# Altium schematic sheet project_buran_schematic.SchDoc
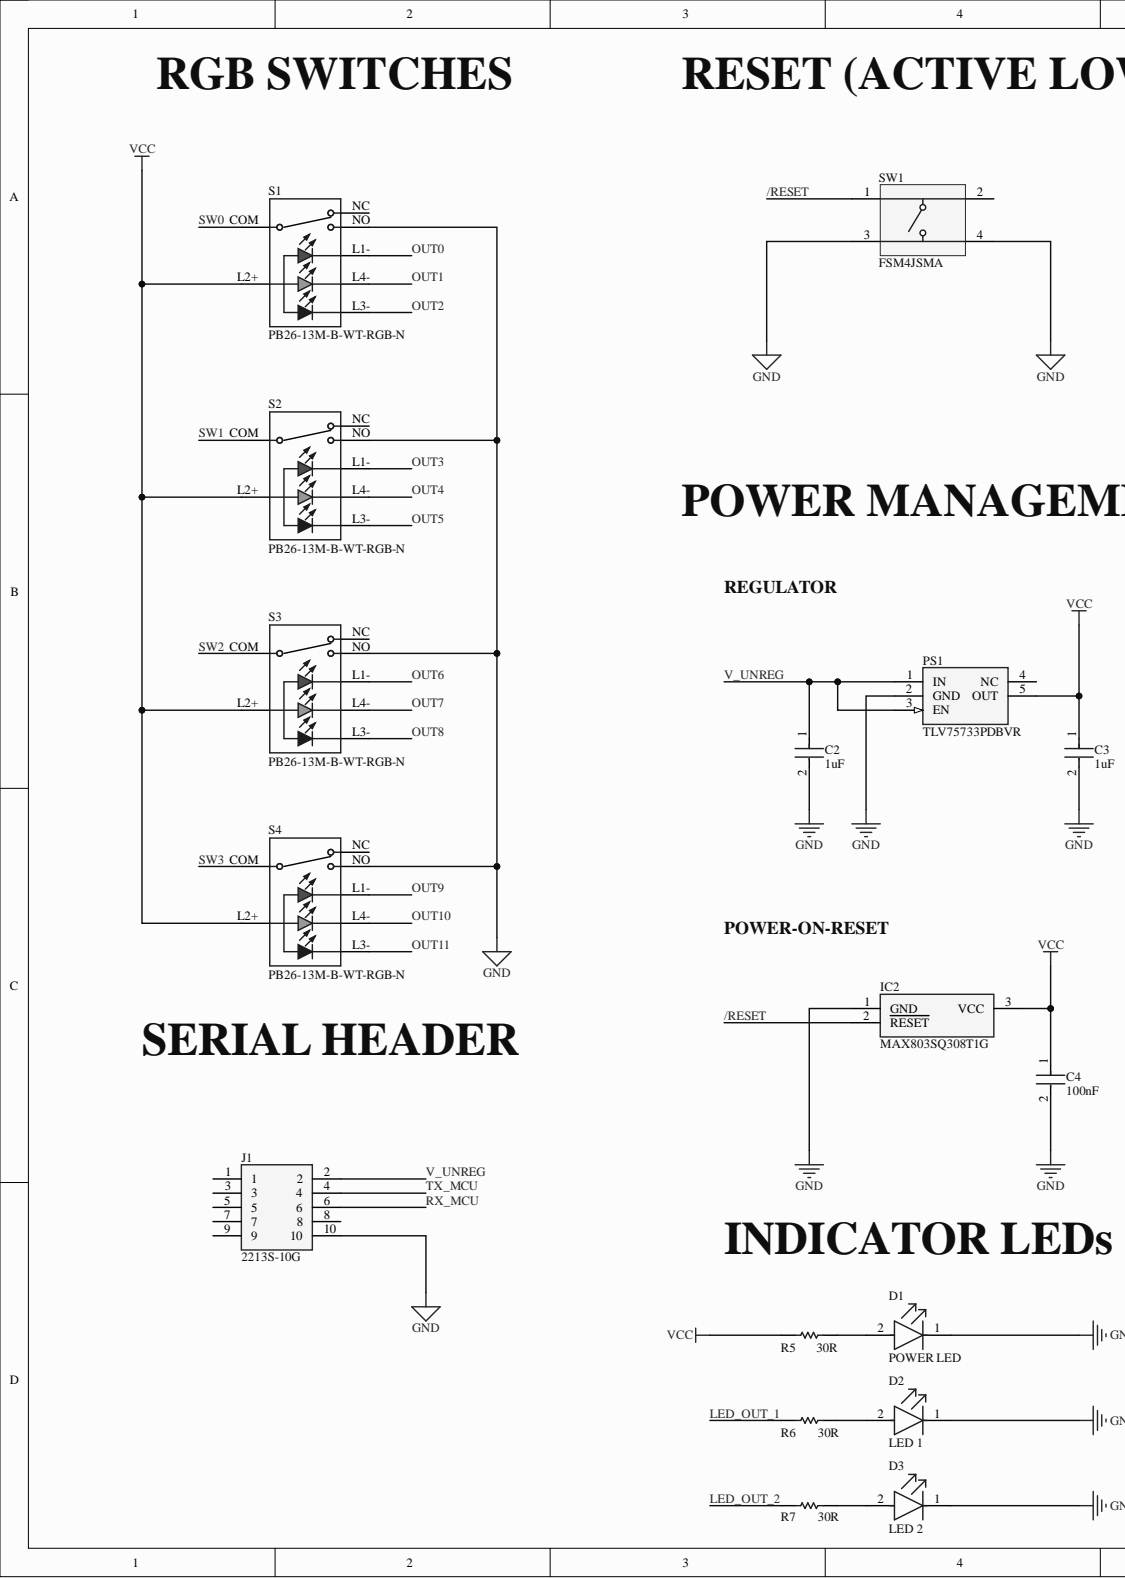
[diagram p0: project_buran_schematic.SchDoc, left]
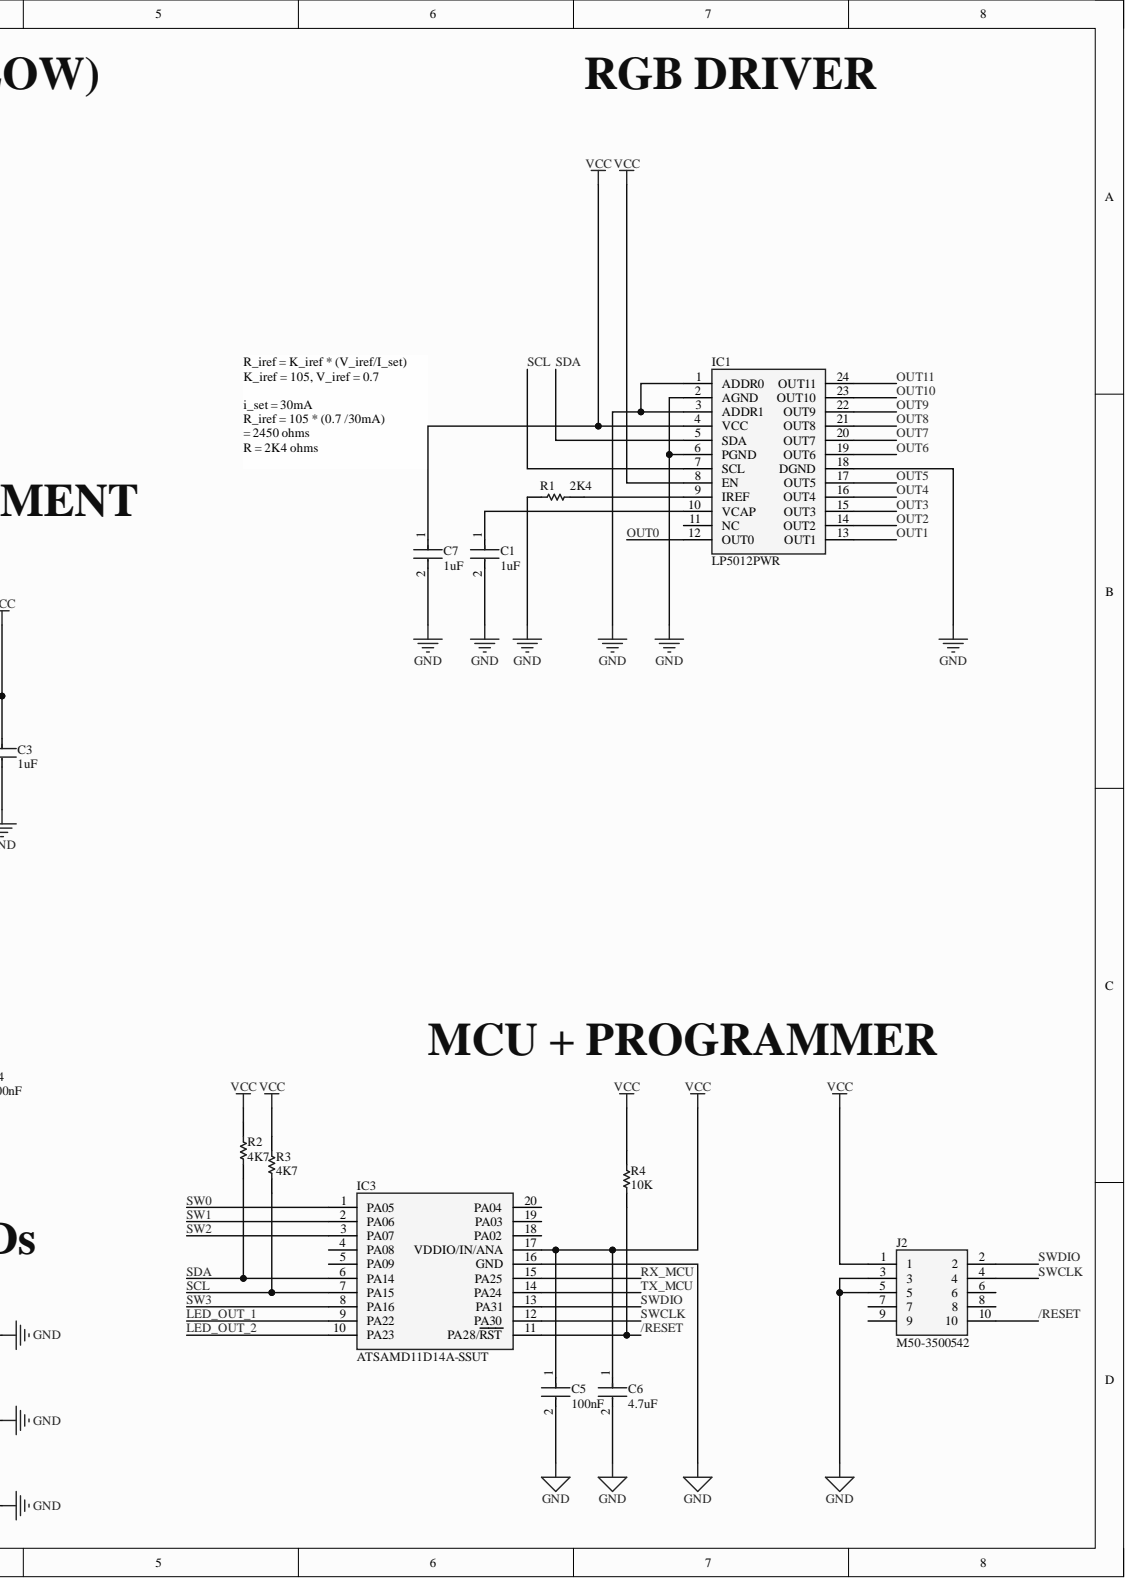
[diagram p1: project_buran_schematic.SchDoc, right]

## Parts
C1  1uF  [FP-GRM188-0_1-e0_2_0_55-IPC_A]
C2  1uF  [FP-GRM188-0_1-e0_2_0_55-IPC_A]
C3  1uF  [FP-GRM188-0_1-e0_2_0_55-IPC_A]
C4  100nF  [FP-GRM188-0_1-e0_2_0_55-IPC_A]
C5  100nF  [FP-GRM188-0_1-e0_2_0_55-IPC_A]
C6  4.7uF  [FP-GRM188-0_1-e0_2_0_55-IPC_A]
C7  1uF  [FP-GRM188-0_1-e0_2_0_55-IPC_A]
D1  POWER LED  [FP-LTST-C190GKT-MFG]
D2  LED 1  [FP-LTST-C190GKT-MFG]
D3  LED 2  [FP-LTST-C190GKT-MFG]
IC1  LP5012PWR  [SOP65P640X120-24N]
IC2  MAX803SQ308T1G  [SOT65P220X100-3N]
IC3  ATSAMD11D14A-SSUT  [SOIC127P1032X265-20N]
J1  2213S-10G  [HDRV10W64P254_2X5_1270X508X854P]
J2  M50-3500542  [M503500542]
PS1  TLV75733PDBVR  [SOT95P280X145-5N]
R1  2K4  [RESC1609X50X30NL10T20]
R2  4K7  [RESC1609X50X30NL10T20]
R3  4K7  [RESC1609X50X30NL10T20]
R4  10K  [RESC1609X50X30NL10T20]
R5  30R  [RESC1609X50X30NL10T20]
R6  30R  [RESC1609X50X30NL10T20]
R7  30R  [RESC1609X50X30NL10T20]
S1  PB26-13M-B-WT-RGB-N  [RGB Switch]
S2  PB26-13M-B-WT-RGB-N  [RGB Switch]
S3  PB26-13M-B-WT-RGB-N  [RGB Switch]
S4  PB26-13M-B-WT-RGB-N  [RGB Switch]
SW1  FSM4JSMA  [TECO-FSM4JSMA_V]

## Nets
GND: C1.2 C2.2 C3.2 C4.2 C5.2 C6.2 C7.2 D1.1 D2.1 D3.1 IC1.1(ADDR0) IC1.2(AGND) IC1.3(ADDR1) IC1.6(PGND) IC1.18(DGND) IC2.1 IC3.16(GND) J1.10 J2.3 J2.5 PS1.2(GND) R1.1 S1.NO S2.NO S3.NO S4.NO SW1.3 SW1.4
VCC: C3.1 C4.1 C5.1 C6.1 C7.1 IC1.4(VCC) IC1.8(EN) IC2.3 IC3.17(VDDIO/IN/ANA) J2.1 PS1.5(OUT) R2.2 R3.2 R4.2 R5.2 S1.L2+ S2.L2+ S3.L2+ S4.L2+
/RESET: IC2.2 IC3.11(PA28/RST) J2.10 R4.1 SW1.1
LED_OUT_1: IC3.9(PA22) R6.2
LED_OUT_2: IC3.10(PA23) R7.2
OUT0: IC1.12(OUT0) S1.L1-
OUT1: IC1.13(OUT1) S1.L4-
OUT2: IC1.14(OUT2) S1.L3-
OUT3: IC1.15(OUT3) S2.L1-
OUT4: IC1.16(OUT4) S2.L4-
OUT5: IC1.17(OUT5) S2.L3-
OUT6: IC1.19(OUT6) S3.L1-
OUT7: IC1.20(OUT7) S3.L4-
OUT8: IC1.21(OUT8) S3.L3-
OUT9: IC1.22(OUT9) S4.L1-
OUT10: IC1.23(OUT10) S4.L4-
OUT11: IC1.24(OUT11) S4.L3-
RX_MCU: IC3.15(PA25) J1.6
SCL: IC1.7(SCL) IC3.7(PA15) R3.1
SDA: IC1.5(SDA) IC3.6(PA14) R2.1
SW0: IC3.1(PA05) S1.COM
SW1: IC3.2(PA06) S2.COM
SW2: IC3.3(PA07) S3.COM
SW3: IC3.8(PA16) S4.COM
SWCLK: IC3.12(PA30) J2.4
SWDIO: IC3.13(PA31) J2.2
TX_MCU: IC3.14(PA24) J1.4
V_UNREG: C2.1 J1.2 PS1.1(IN) PS1.3(EN)
NetC1_1: C1.1 IC1.10(VCAP)
NetD1_2: D1.2 R5.1
NetD2_2: D2.2 R6.1
NetD3_2: D3.2 R7.1
NetIC1_9: IC1.9(IREF) R1.2

Not connected: IC1 11(NC); IC3 4(PA08) 5(PA09) 18(PA02) 19(PA03) 20(PA04); J1 1 3 5 7 8 9; J2 6 7 8 9; PS1 4(NC); S1 NC; S2 NC; S3 NC; S4 NC; SW1 2

## Library data of the parts
C1-C7 = CMP-2006-02792-2: Chip Multilayer Ceramic Capacitors for General Purpose, 0603, 10uF, X5R, 15%, 20%, 6.3V; Capacitance: 10uF; Case Code (Imperial): 0603; Case Code (Metric): 1608; Case/Package: 0603; Component Kind: Standard; Depth: 0.8mm; Dielectric: X5R; Height: 0.8mm; Lead Free: Lead Free; Length: 1.6mm; Library Name: Altium Content Vault; Material: Ceramic; Max Operating Temperature: 85°C; Min Operating Temperature: -55°C; Mount: Surface Mount; Package Quantity: 4000; Packaging: Tape and Reel; Radiation Hardening: No; REACH SVHC: No SVHC; Termination: SMD/SMT; Thickness: 0.035inch; Tolerance: 20%; Voltage: 6.3V; Voltage Rating: 6.3V; Voltage Rating (DC): 6.3V; Width: 0.031inch
D1-D3 = CMP-22017-000005-2: LED GREEN CLEAR CHIP SMD; Dominant Wavelength: 569nm; Forward Current: 30mA; Forward Voltage: 2.1V; Lens Color: Colorless; Luminous Intensity: 6mcd; Number of Elements: 1; Power Dissipation: 100mW; Reverse Voltage: 5V; Test Current: 10mA; Viewing Angle: 130°; Voltage: 2V; Wavelength: 565nm; Case Code (Imperial): 0603; Case Code (Metric): 1608; Case/Package: 0603; Color: Green; Component Kind: Standard; Depth: 0.8mm; Height: 0.8mm; Illumination Color: Green; Lead Free: Lead Free; Length: 1.6mm; Lens Style: Clear; Lens Transparency: Clear; Library Name: Altium Content Vault; Max Operating Temperature: 85°C; Min Operating Temperature: -55°C; Mount: Surface Mount; Number of LEDs: 1; Number of Pins: 2; Package Quantity: 1; Packaging: Tape and Reel; Power Rating: 100mW; Radiation Hardening: No; Width: 0.8mm
IC1 = LP5012PWR: Integrated Circuit; Manufacturer_Name: Texas Instruments; Component Kind: Standard; Datasheet Link: https://www.ti.com/lit/ds/symlink/lp5012.pdf?ts=1615929314042&ref_url=https%253A%252F%252Fwww.mouser.co.uk%252F; Height: 1.2mm; Manufacturer_Part_Number: LP5012PWR; Mouser Part Number: 595-LP5012PWR; Mouser Price/Stock: https://www.mouser.co.uk/ProductDetail/Texas-Instruments/LP5012PWR/?qs=T94vaHKWudSL6jLwBy12Hg%3D%3D
IC2 = MAX803SQ308T1G: Integrated Circuit; Manufacturer_Name: ON Semiconductor; Arrow Part Number: MAX803SQ308T1G; Arrow Price/Stock: https://www.arrow.com/en/products/max803sq308t1g/on-semiconductor; Component Kind: Standard; Datasheet Link: http://www.onsemi.com/pub/Collateral/MAX803-D.PDF; Height: 1mm; Manufacturer_Part_Number: MAX803SQ308T1G; Mouser Part Number: 863-MAX803SQ308T1G; Mouser Price/Stock: https://www.mouser.co.uk/ProductDetail/ON-Semiconductor/MAX803SQ308T1G?qs=aSUclzrs14xj4ys5ck7Tew%3D%3D
IC3 = ATSAMD11D14A-SSUT: Integrated Circuit; Manufacturer_Name: Microchip; Arrow Part Number: ATSAMD11D14A-SSUT; Arrow Price/Stock: https://www.arrow.com/en/products/atsamd11d14a-ssut/microchip-technology; Component Kind: Standard; Datasheet Link: http://ww1.microchip.com/downloads/en/devicedoc/atmel-42363-sam-d11_datasheet.pdf; Height: 2.65mm; Manufacturer_Part_Number: ATSAMD11D14A-SSUT; Mouser Part Number: 556-ATSAMD11D14ASSUT; Mouser Price/Stock: https://www.mouser.co.uk/ProductDetail/Microchip-Technology-Atmel/ATSAMD11D14A-SSUT/?qs=lrqjml%252Bjt8f8EFewxXrzCw%3D%3D
J1 = 2213S-10G: Connector; Manufacturer_Name: MULTICOMP; Component Kind: Standard; Datasheet Link: http://www.farnell.com/datasheets/1697536.pdf; Height: 8.54mm; Manufacturer_Part_Number: 2213S-10G
J2 = M50-3500542: Connector; Manufacturer_Name: Harwin; Component Kind: Standard; Datasheet Link: http://docs-emea.rs-online.com/webdocs/13d4/0900766b813d4011.pdf; Height: 4.15mm; Library Name: SamacSys.SchLib; Manufacturer_Part_Number: M50-3500542; Mouser Part Number: 855-M50-3500542; Mouser Price/Stock: https://www.mouser.co.uk/ProductDetail/Harwin/M50-3500542?qs=9fQaSFfsqsyXI0P9tFOVoQ%3D%3D
PS1 = TLV75733PDBVR: Power Supply; Manufacturer_Name: Texas Instruments; Arrow Part Number: TLV75733PDBVR; Arrow Price/Stock: https://www.arrow.com/en/products/tlv75733pdbvr/texas-instruments; Component Kind: Standard; Datasheet Link: http://www.ti.com/lit/gpn/tlv757p; Height: 1.45mm; Library Name: SamacSys.SchLib; Manufacturer_Part_Number: TLV75733PDBVR; Mouser Part Number: 595-TLV75733PDBVR; Mouser Price/Stock: https://www.mouser.co.uk/ProductDetail/Texas-Instruments/TLV75733PDBVR/?qs=y6ZabgHbY%252BwAAzSntTW34w%3D%3D
R1-R7 = CMP-2000-03854-1: Manufacturer: Vishay Dale; Part Number: CRCW0603110RFKEA; Component Kind: Standard; Library Name: Altium Content Vault
S1-S4 = PB26-13M-B-WT-RGB-N: WS-PBTL Illuminated THT Push Button Switch, Red & Green & Blue; Part Number: 465M111172501; Operation Force: 200gf; Rated Current: 100mA; Rated Voltage: 12VDC; Component Kind: Standard; ComponentLink1Description: Manufacturer; ComponentLink1URL: http://www.we-online.de; ComponentLink2Description: Datasheet; ComponentLink2URL: https://katalog.we-online.com/em/datasheet/465M111172501.pdf; Contact Material: Copper Alloy; Contact Plating: Gold; Emitting Color: Red & Green & Blue; Mounting Technology: THT; Operating Temperature: -40 to +85degC; Packaging: ESD Tray; Published Date: September 2019; Size: 15x15mm; Terminal Material: Copper Alloy; Terminal Plating: Tin; Library Name: BuranComponent.SchLib; Publisher: Wurth Elektronik
SW1 = CMP-2000-05602-2: Swicth, SPST, 24 V, -35 to 85 degC, 4-Pin SMD, RoHS, Tape and Reel; Manufacturer: TE Connectivity; Actuator Length: 0.055inch; Actuator Material: Polyamide; Case\/Package: SMD/SMT; Contact Current Rating: 50mA; Contact Resistance: 100mOhm; Electrical Life: 100000Cycles; Illumination Type: Illuminated; Max Voltage Rating (DC): 12V; Operating Force: 1.57N; Switch Travel: 0.25mm; Voltage Rating: 24V; Component Kind: Standard; Contact Material: CopperAlloy; Contact Plating: Silver; Current Rating: 50mA; Datasheet URL: http://www.te.com/commerce/DocumentDelivery/DDEController?Action=showdoc&DocId=Customer+Drawing%7F2-1437565-7%7FV%7Fpdf%7FEnglish%7FENG_CD_2-1437565-7_V.pdf%7F4-1437565-2; Datasheet Version: Rev. V, 02/2015; Length: 6mm; Library Name: Altium Content Vault; Manufacturer URL: http://www.te.com/; Max Operating Temperature: 85degC; Mechanical Life: 100000Cycles; Min Operating Temperature: -35degC; Mount: SurfaceMount; Mounting Technology: SurfaceMount; Orientation: Straight; Package Description: 4-Pin Surface Mount Device, Body 6 x 6 mm, Pitch 4.5 mm; Package Quantity: 750; Package Reference: FSM4JSMA; Packaging: LoosePiece; REACH SVHC: Unknown; RoHSCompliant: true; Termination: GullWing; Throw Configuration: SPST; Voltage Rating (DC): 24V; Width: 6mm
